# Altium schematic sheet TempSensors.SchDoc(TempSensors)
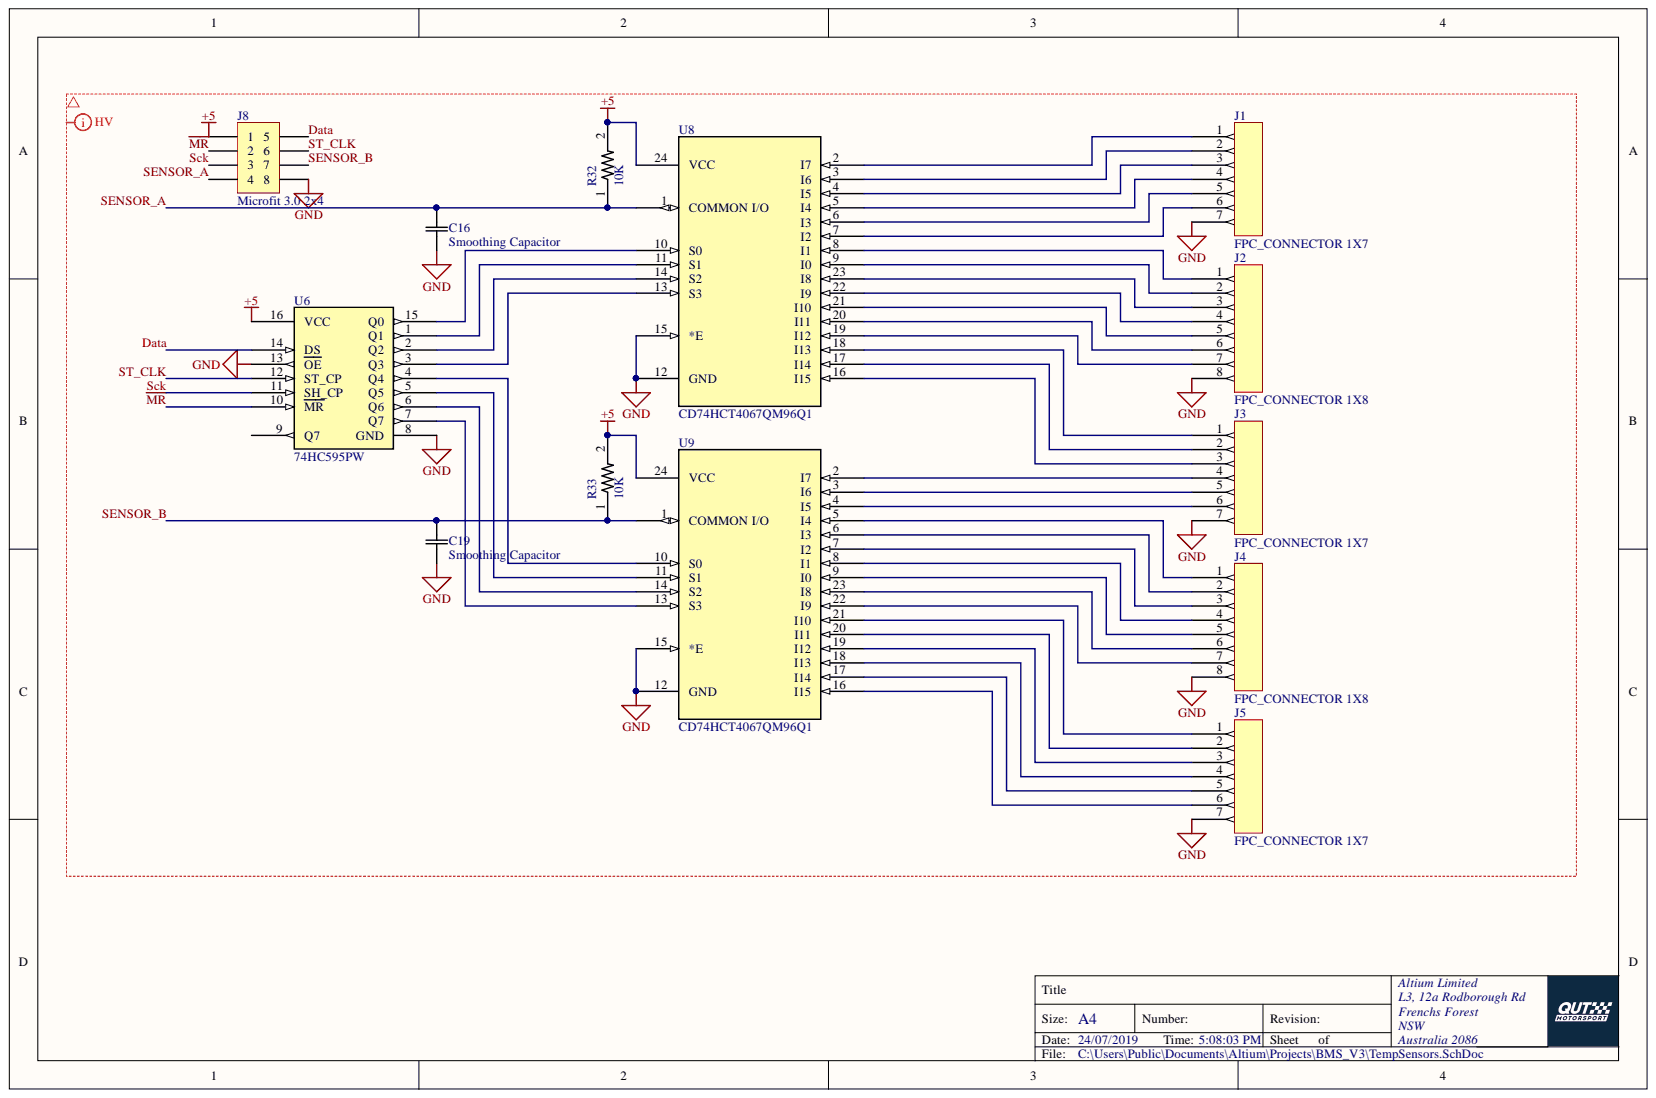
[diagram p0: TempSensors.SchDoc]

## Parts
C16  Smoothing Capacitor  [cap_UMK107ABJ400]
C19  Smoothing Capacitor  [cap_UMK107ABJ400]
J1  FPC_CONNECTOR 1X7  [FPC_CONNECTOR 1X7]
J2  FPC_CONNECTOR 1X8  [FPC_CONNECTOR 1X8]
J3  FPC_CONNECTOR 1X7  [FPC_CONNECTOR 1X7]
J4  FPC_CONNECTOR 1X8  [FPC_CONNECTOR 1X8]
J5  FPC_CONNECTOR 1X7  [FPC_CONNECTOR 1X7]
J8  Microfit 3.0 2x4  [Microfit_2X4_V_SMD]
R32  10K  [RESC1608X55N]
R33  10K  [RESC1608X55N]
U6  74HC595PW  [SOT403-1]
U8  CD74HCT4067QM96Q1  [DW24]
U9  CD74HCT4067QM96Q1  [DW24]

## Nets
+5: J8.1 R32.2 R33.2 U6.16(VCC) U8.24(VCC) U9.24(VCC)
GND: C16.2 C19.2 J1.7 J2.8 J3.7 J4.8 J5.7 J8.8 U6.8(GND) U6.13(OE) U8.12(GND) U8.15(*E) U9.12(GND) U9.15(*E)
Data: J8.5 U6.14(DS)
~{MR}: J8.2 U6.10(MR)
Sck: J8.3 U6.11(SH_CP)
SENSOR_A: C16.1 J8.4 R32.1 U8.1
SENSOR_B: C19.1 J8.7 R33.1 U9.1
ST_CLK: J8.6 U6.12(ST_CP)
NetJ1_1: J1.1 U8.2(I7)
NetJ1_2: J1.2 U8.3(I6)
NetJ1_3: J1.3 U8.4(I5)
NetJ1_4: J1.4 U8.5(I4)
NetJ1_5: J1.5 U8.6(I3)
NetJ1_6: J1.6 U8.7(I2)
NetJ2_1: J2.1 U8.8(I1)
NetJ2_2: J2.2 U8.9(I0)
NetJ2_3: J2.3 U8.23(I8)
NetJ2_4: J2.4 U8.22(I9)
NetJ2_5: J2.5 U8.21(I10)
NetJ2_6: J2.6 U8.20(I11)
NetJ2_7: J2.7 U8.19(I12)
NetJ3_1: J3.1 U8.18(I13)
NetJ3_2: J3.2 U8.17(I14)
NetJ3_3: J3.3 U8.16(I15)
NetJ3_4: J3.4 U9.2(I7)
NetJ3_5: J3.5 U9.3(I6)
NetJ3_6: J3.6 U9.4(I5)
NetJ4_1: J4.1 U9.5(I4)
NetJ4_2: J4.2 U9.6(I3)
NetJ4_3: J4.3 U9.7(I2)
NetJ4_4: J4.4 U9.8(I1)
NetJ4_5: J4.5 U9.9(I0)
NetJ4_6: J4.6 U9.23(I8)
NetJ4_7: J4.7 U9.22(I9)
NetJ5_1: J5.1 U9.21(I10)
NetJ5_2: J5.2 U9.20(I11)
NetJ5_3: J5.3 U9.19(I12)
NetJ5_4: J5.4 U9.18(I13)
NetJ5_5: J5.5 U9.17(I14)
NetJ5_6: J5.6 U9.16(I15)
NetU6_1: U6.1(Q1) U8.11(S1)
NetU6_2: U6.2(Q2) U8.14(S2)
NetU6_3: U6.3(Q3) U8.13(S3)
NetU6_4: U6.4(Q4) U9.10(S0)
NetU6_5: U6.5(Q5) U9.11(S1)
NetU6_6: U6.6(Q6) U9.14(S2)
NetU6_7: U6.7(Q7) U9.13(S3)
NetU6_15: U6.15(Q0) U8.10(S0)
N00019:

Not connected: U6 9(Q7)

## Library data of the parts
C16 C19 = EMK107BJ105KA-T: Placeholder to allow for a smoothing capacitor if needed; Vendor: Taiyo Yuden; Type: DEV; Built_By: EMA_UL_Team; Value: Value; RefDes: RefDes; Manufacturer_Part_Number: EMK107BJ105KA-T; Library Name: Capacitors.SchLib; Component Kind: Standard; Copyright: Copyright (C) 2018 Accelerated Designs. All rights reserved
J8 = Microfit_2x4: Component Kind: Standard; Library Name: QUTMS_Microfit.SchLib
R32 R33 = 10K: Res Thick Film 0603 10K Ohm 5% 0.25W_1/4W_ Â±200ppm/C Molded SMD Automotive T/R; MP: ESR03EZPJ103; MF: Rohm; SnapEDA_Link: https://www.snapeda.com/parts/ESR03EZPJ103/Rohm/view-part/?ref=snap; Price: None; Package: 0603 Rohm; Library Name: 10K Resistor.SchLib; Component Kind: Standard; Availability: Unavailable
U6 = 74HC595PW: No Description Available; Vendor: Nexperia; Value: Value; Type: DEV; RefDes: RefDes; Manufacturer_Part_Number: 74HC595PW; Library Name: 74HC595PW.SchLib; Component Kind: Standard; Copyright: Copyright (C) 2016 Accelerated Designs. All rights reserved
U8 U9 = CD74HCT4067QM96Q: No Description Available; Vendor: Texas Instruments; Built_By: EMA_UL_Team; Value: Value; Type: DEV; RefDes: RefDes; Manufacturer_Part_Number: CD74HCT4067QM96Q1; Manufacturer: Texas Instruments; Component Kind: Standard; Copyright: Copyright (C) 2018 Accelerated Designs. All rights reserved
J1 J3 J5 = FPC_CONNECTOR 1X7: Library Name: FPC_CONNECTORS.SchLib; Component Kind: Standard
J2 J4 = FPC_CONNECTOR 1X8: Library Name: FPC_CONNECTORS.SchLib; Component Kind: Standard
